# Altium schematic sheet BM20_Rev0_CellSimulator.SchDoc
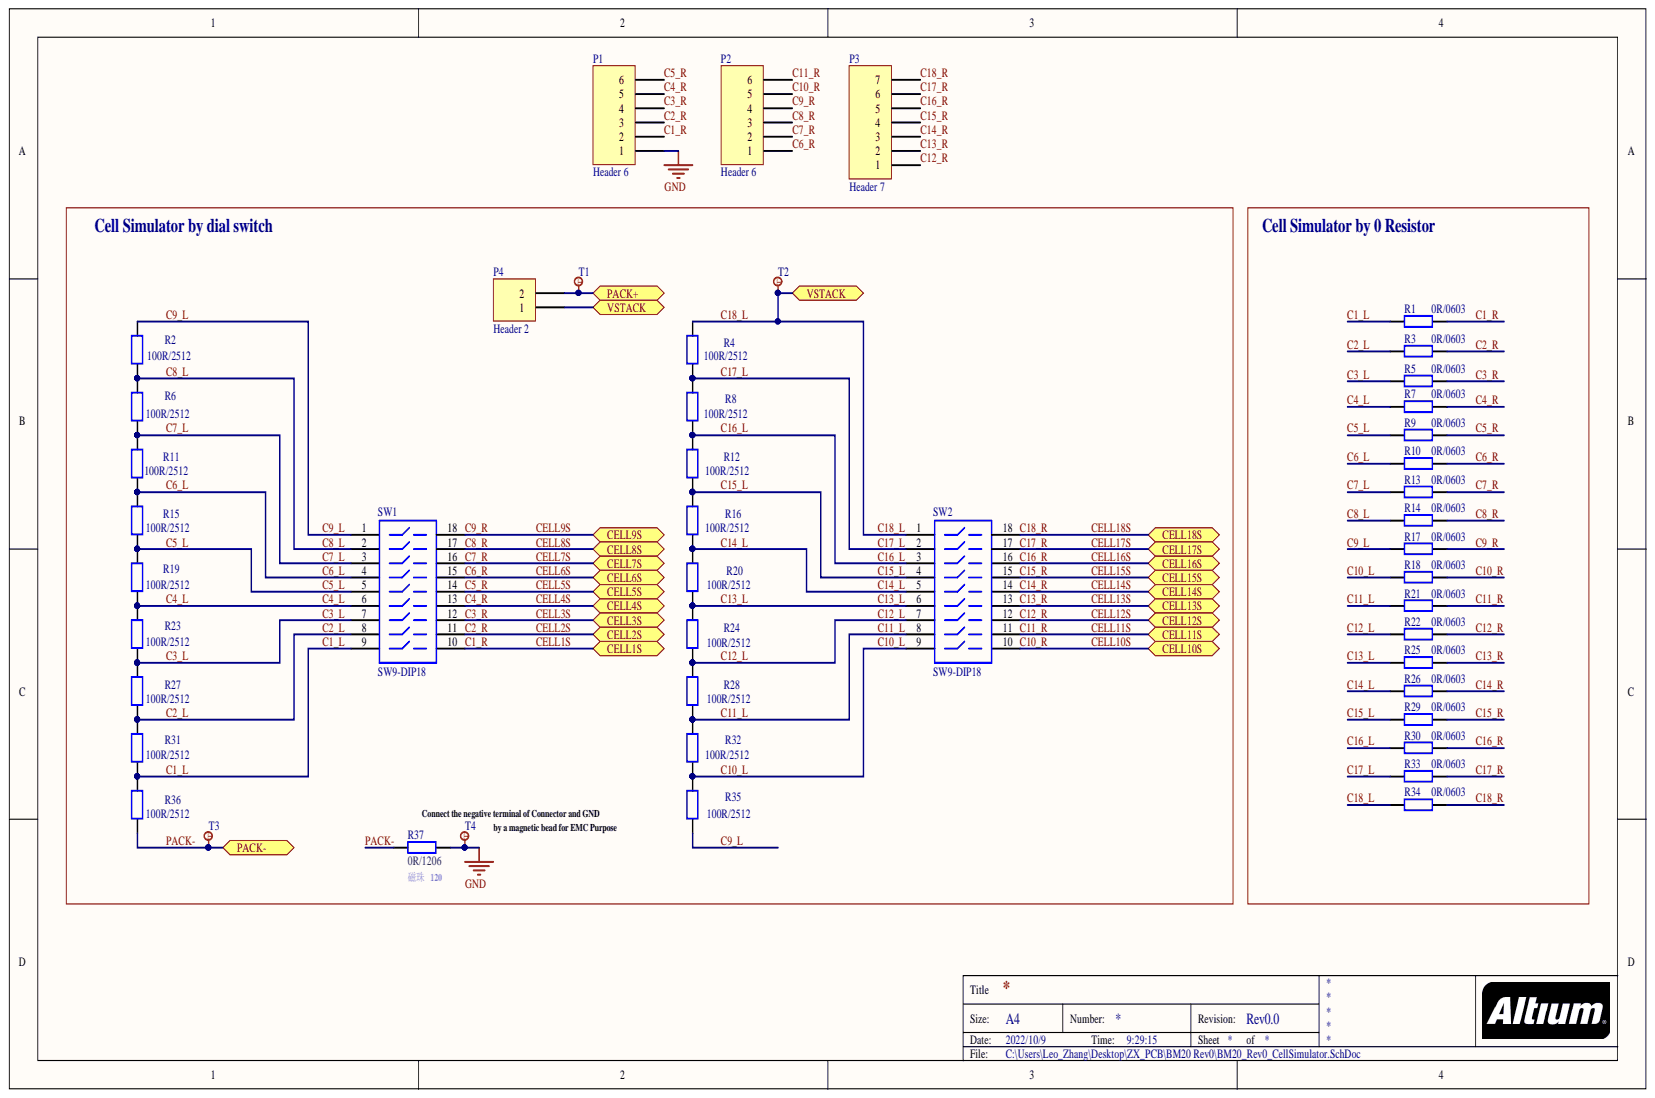
[diagram p0: BM20_Rev0_CellSimulator.SchDoc]

## Parts
P1  Header 6  [HDR1X6]
P2  Header 6  [HDR1X6]
P3  Header 7  [HDR1X7]
P4  Header 2  [HDR1X2]
R1  0R/0603  [0603_R]
R2  100R/2512  [2512_R]
R3  0R/0603  [0603_R]
R4  100R/2512  [2512_R]
R5  0R/0603  [0603_R]
R6  100R/2512  [2512_R]
R7  0R/0603  [0603_R]
R8  100R/2512  [2512_R]
R9  0R/0603  [0603_R]
R10  0R/0603  [0603_R]
R11  100R/2512  [2512_R]
R12  100R/2512  [2512_R]
R13  0R/0603  [0603_R]
R14  0R/0603  [0603_R]
R15  100R/2512  [2512_R]
R16  100R/2512  [2512_R]
R17  0R/0603  [0603_R]
R18  0R/0603  [0603_R]
R19  100R/2512  [2512_R]
R20  100R/2512  [2512_R]
R21  0R/0603  [0603_R]
R22  0R/0603  [0603_R]
R23  100R/2512  [2512_R]
R24  100R/2512  [2512_R]
R25  0R/0603  [0603_R]
R26  0R/0603  [0603_R]
R27  100R/2512  [2512_R]
R28  100R/2512  [2512_R]
R29  0R/0603  [0603_R]
R30  0R/0603  [0603_R]
R31  100R/2512  [2512_R]
R32  100R/2512  [2512_R]
R33  0R/0603  [0603_R]
R34  0R/0603  [0603_R]
R35  100R/2512  [2512_R]
R36  100R/2512  [2512_R]
R37  0R/1206  [1206_R]
SW1  SW9-DIP18  [Switch9X2]
SW2  SW9-DIP18  [Switch9X2]
T1  Test  [TP_Point]  (value not drawn)
T2  Test  [TP_Point]  (value not drawn)
T3  Test  [TP_Point]  (value not drawn)
T4  Test  [TP_GND]  (value not drawn)

## Ports
CELL1S, CELL2S, CELL3S, CELL4S, CELL5S, CELL6S, CELL7S, CELL8S, CELL9S, CELL10S, CELL11S, CELL12S, CELL13S, CELL14S, CELL15S, CELL16S, CELL17S, CELL18S, PACK+, PACK-, VSTACK

## Nets
GND: P1.1 R37.2 T4.0
C1_L: R1.1 R31.1 R36.2 SW1.9
C1_R: P1.2 R1.2 SW1.10 ; port CELL1S
C2_L: R3.1 R27.1 R31.2 SW1.8
C2_R: P1.3 R3.2 SW1.11 ; port CELL2S
C3_L: R5.1 R23.1 R27.2 SW1.7
C3_R: P1.4 R5.2 SW1.12 ; port CELL3S
C4_L: R7.1 R19.1 R23.2 SW1.6
C4_R: P1.5 R7.2 SW1.13 ; port CELL4S
C5_L: R9.1 R15.1 R19.2 SW1.5
C5_R: P1.6 R9.2 SW1.14 ; port CELL5S
C6_L: R10.1 R11.1 R15.2 SW1.4
C6_R: P2.1 R10.2 SW1.15 ; port CELL6S
C7_L: R6.1 R11.2 R13.1 SW1.3
C7_R: P2.2 R13.2 SW1.16 ; port CELL7S
C8_L: R2.1 R6.2 R14.1 SW1.2
C8_R: P2.3 R14.2 SW1.17 ; port CELL8S
C9_L: R2.2 R17.1 R35.1 SW1.1
C9_R: P2.4 R17.2 SW1.18 ; port CELL9S
C10_L: R18.1 R32.1 R35.2 SW2.9
C10_R: P2.5 R18.2 SW2.10 ; port CELL10S
C11_L: R21.1 R28.1 R32.2 SW2.8
C11_R: P2.6 R21.2 SW2.11 ; port CELL11S
C12_L: R22.1 R24.1 R28.2 SW2.7
C12_R: P3.1 R22.2 SW2.12 ; port CELL12S
C13_L: R20.1 R24.2 R25.1 SW2.6
C13_R: P3.2 R25.2 SW2.13 ; port CELL13S
C14_L: R16.1 R20.2 R26.1 SW2.5
C14_R: P3.3 R26.2 SW2.14 ; port CELL14S
C15_L: R12.1 R16.2 R29.1 SW2.4
C15_R: P3.4 R29.2 SW2.15 ; port CELL15S
C16_L: R8.1 R12.2 R30.1 SW2.3
C16_R: P3.5 R30.2 SW2.16 ; port CELL16S
C17_L: R4.1 R8.2 R33.1 SW2.2
C17_R: P3.6 R33.2 SW2.17 ; port CELL17S
C18_L: P4.1 R4.2 R34.1 SW2.1 T2.0 ; port VSTACK
C18_R: P3.7 R34.2 SW2.18 ; port CELL18S
PACK-: R36.1 R37.1 T3.0 ; port PACK-
NetP4_2: P4.2 T1.0

## Library data of the parts
P1 P2 = Header 6: Header, 6-Pin; Component Kind: Standard; Library Name: Miscellaneous Connectors.IntLib; Signal Integrity: Connector
P3 = Header 7: Header, 7-Pin; Component Kind: Standard; Library Name: Miscellaneous Connectors.IntLib; Signal Integrity: Connector
P4 = Header 2: Header, 2-Pin; Component Kind: Standard; Library Name: Miscellaneous Connectors.IntLib; Signal Integrity: Connector
R1-R37 = Resistor: 贴片电阻; Component Kind: Standard; Library Name: Schlib1.SchLib
SW1 SW2 = SW9-DIP18: Component Kind: Standard; Library Name: BM02 Rev0.SchLib
T1-T4 = Test: Component Kind: Standard; Library Name: 17S.SchLib
